# Altium schematic sheet Sch-QWA17_CAM0_1.SchDoc(Sch-QWA17_CAM0_1)
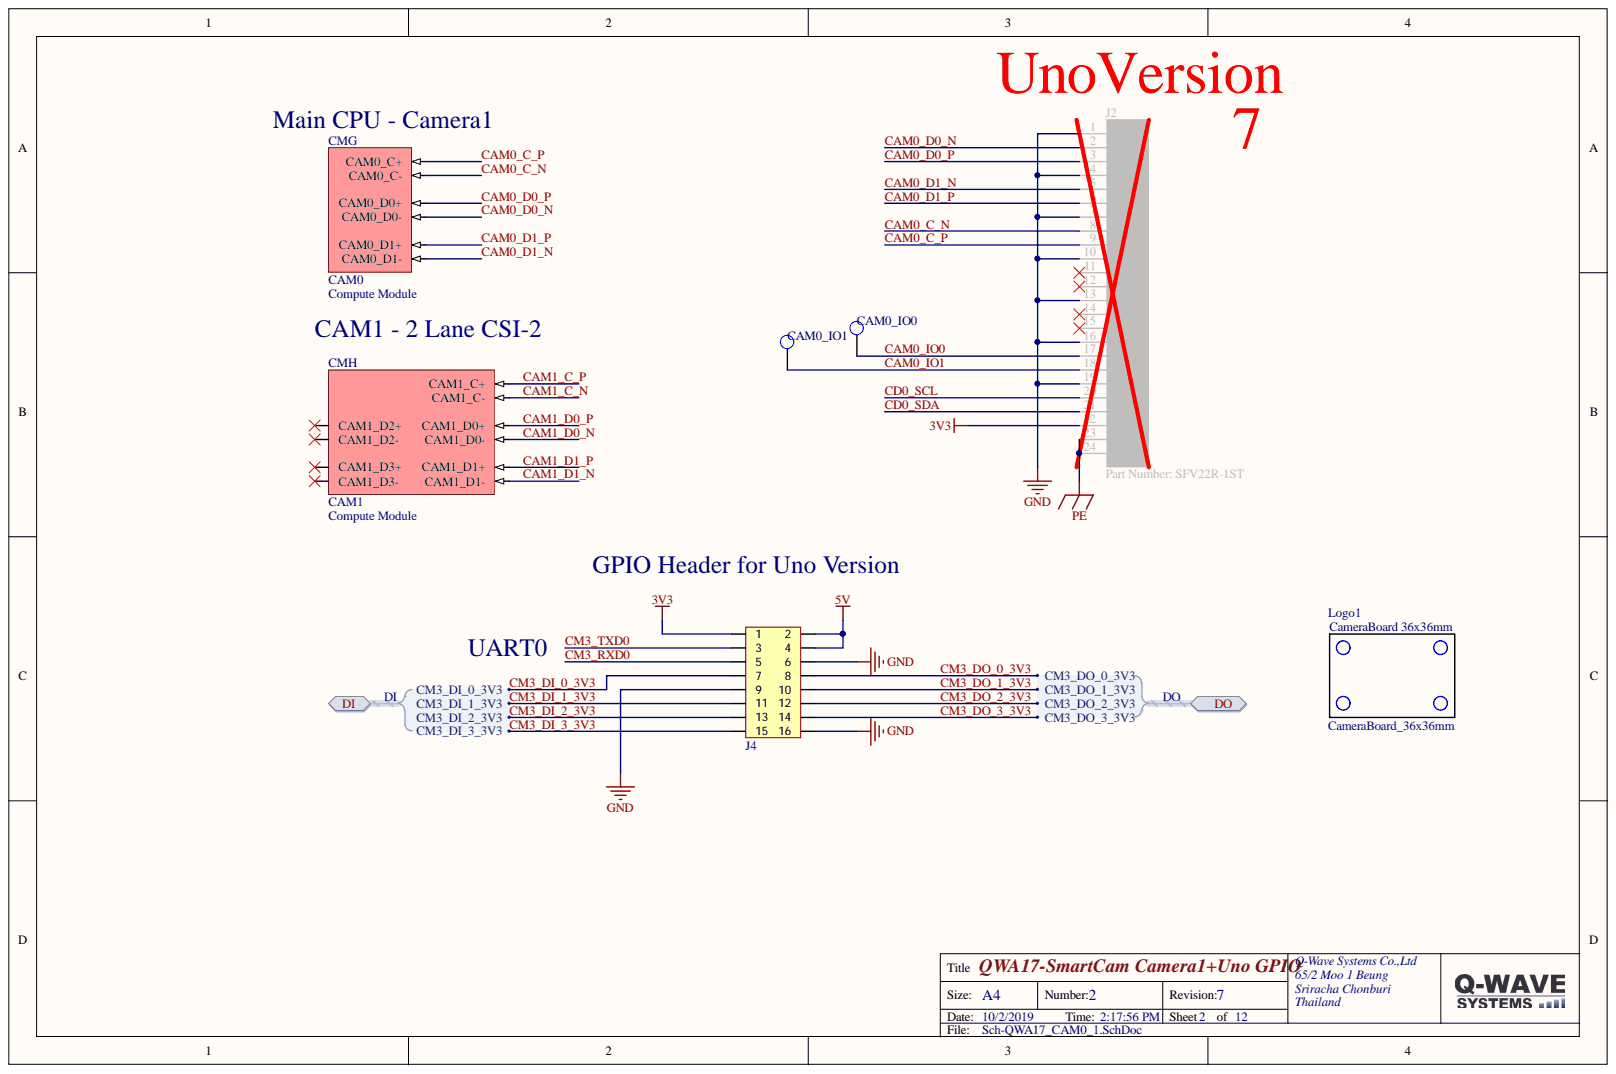
[diagram p0: Sch-QWA17_CAM0_1.SchDoc]

## Parts
CAM0_IO0  CAM0_IO0  [TEST_POINT]
CAM0_IO1  CAM0_IO1  [TEST_POINT]
CM  Compute Module  [1473005-4]  (parts G,H)
J2  Part Number: SFV22R-1ST  [CON22_1X22_DR_SF]
J4  HDR2x8_2.54  [HDR2X8_2.54_180]  (value not drawn)
Logo1  CameraBoard_36x36mm  [Qwave_Logo1]

## Ports
DI, DI.CM3_DI_0_3V3, DI.CM3_DI_1_3V3, DI.CM3_DI_2_3V3, DI.CM3_DI_3_3V3, DO, DO.CM3_DO_0_3V3, DO.CM3_DO_1_3V3, DO.CM3_DO_2_3V3, DO.CM3_DO_3_3V3

## Nets
3V3: J2.22 J4.1
5V: J4.2 J4.4
GND: J2.1 J2.4 J2.7 J2.10 J2.13 J2.16 J2.19 J4.6 J4.9 J4.16
PE: J2.23 J2.24
CAM0_C_N: CM.144(CAM0_C-) J2.8
CAM0_C_P: CM.142(CAM0_C+) J2.9
CAM0_D0_N: CM.138(CAM0_D0-) J2.2
CAM0_D0_P: CM.136(CAM0_D0+) J2.3
CAM0_D1_N: CM.150(CAM0_D1-) J2.5
CAM0_D1_P: CM.148(CAM0_D1+) J2.6
CAM0_IO0: CAM0_IO0.1 J2.17
CAM0_IO1: CAM0_IO1.1 J2.18
CAM1_C_N: CM.149(CAM1_C-)
CAM1_C_P: CM.147(CAM1_C+)
CAM1_D0_N: CM.161(CAM1_D0-)
CAM1_D0_P: CM.159(CAM1_D0+)
CAM1_D1_N: CM.155(CAM1_D1-)
CAM1_D1_P: CM.153(CAM1_D1+)
CD0_SCL: J2.20
CD0_SDA: J2.21
CM3_DI_0_3V3: J4.7 ; port DI
CM3_DI_1_3V3: J4.11 ; port DI
CM3_DI_2_3V3: J4.13 ; port DI
CM3_DI_3_3V3: J4.15 ; port DI
CM3_DO_0_3V3: J4.8 ; port DO
CM3_DO_1_3V3: J4.10 ; port DO
CM3_DO_2_3V3: J4.12 ; port DO
CM3_DO_3_3V3: J4.14 ; port DO
CM3_RXD0: J4.5
CM3_TXD0: J4.3

Not connected: CM 135(CAM1_D3+) 137(CAM1_D3-) 141(CAM1_D2+) 143(CAM1_D2-); J2 11 12 14 15

## Library data of the parts
CAM0_IO0 CAM0_IO1 = TEST_POINT: Test Point; Component Kind: Standard; Library Name: Misc.SCHLIB
CM = Compute Module: Raspberry Pi Compute Module; Component Kind: Standard; Library Name: Compute Module.SchLib
J2 = SFV22R-1ST: SFV22R-1STBE1HLF FPC Connectors 22pin 0.5mm putch; Built_By: EMA_UL_Team; Vendor: FCI; Component Kind: Standard; Library Name: FFC_22_0.5_SFV22R-1STBE1HLF.IntLib; Manufacturer_Part_Number: SFV22R-1ST; RefDes: RefDes; Type: DEV; Value: Value; Copyright: Copyright (C) 2018 Accelerated Designs. All rights reserved
J4 = HDR2x8_2.54: Header; Component Kind: Standard; Library Name: SCH_ConnectorHDR.SchLib
Logo1 = CameraBoard_36x36mm: Component Kind: Standard; Library Name: QwaveLib.SchLib
